# Altium schematic sheet communication.SchDoc
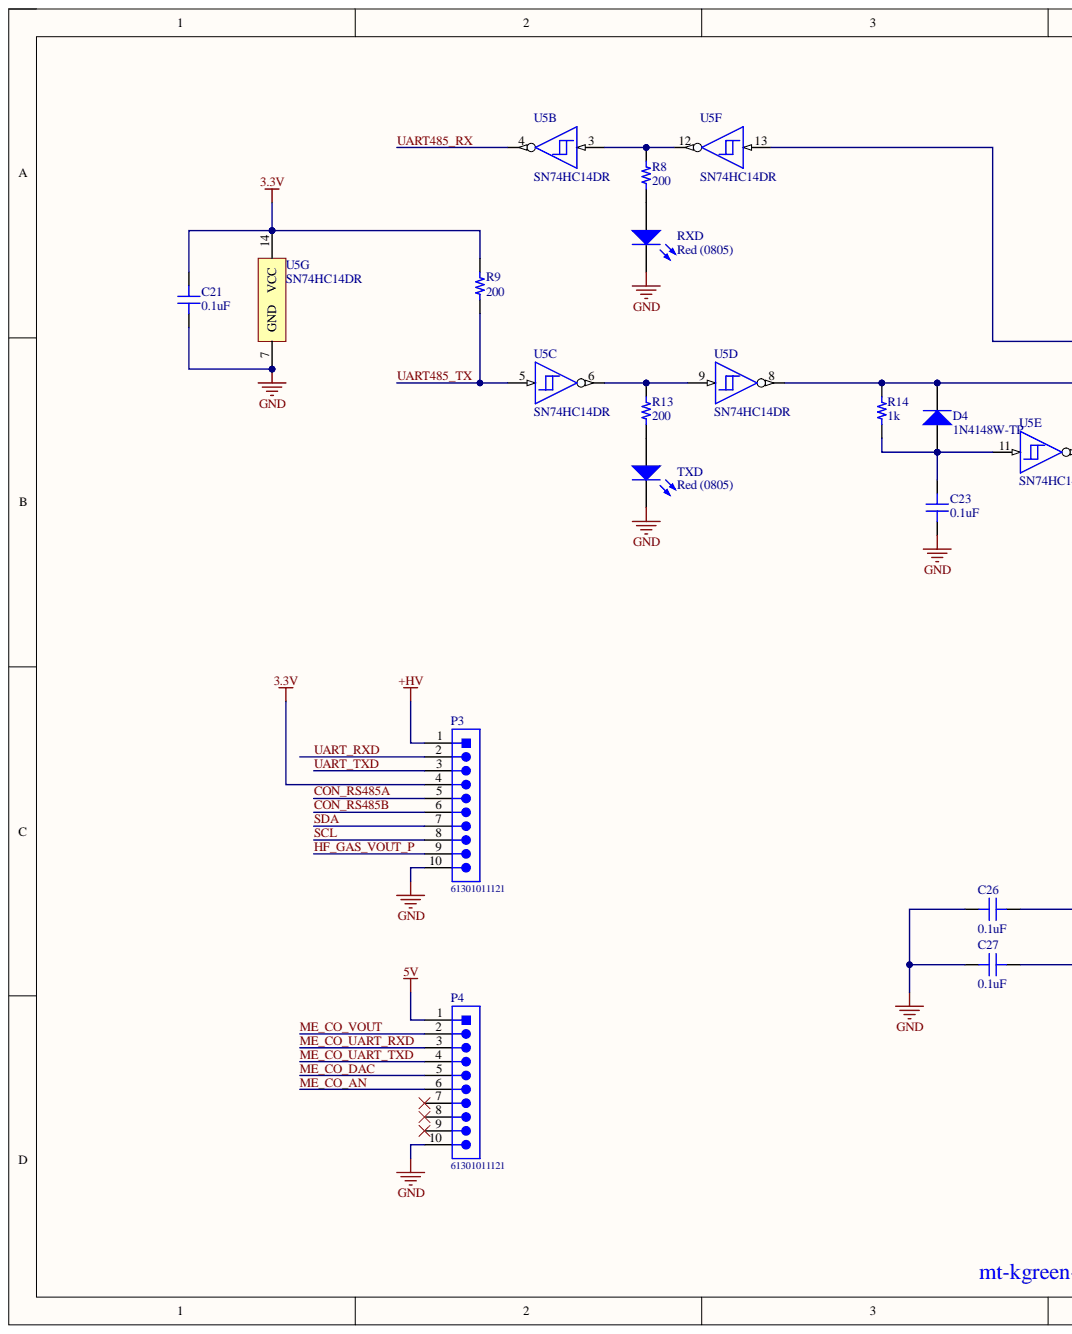
[diagram p0: communication.SchDoc, left]
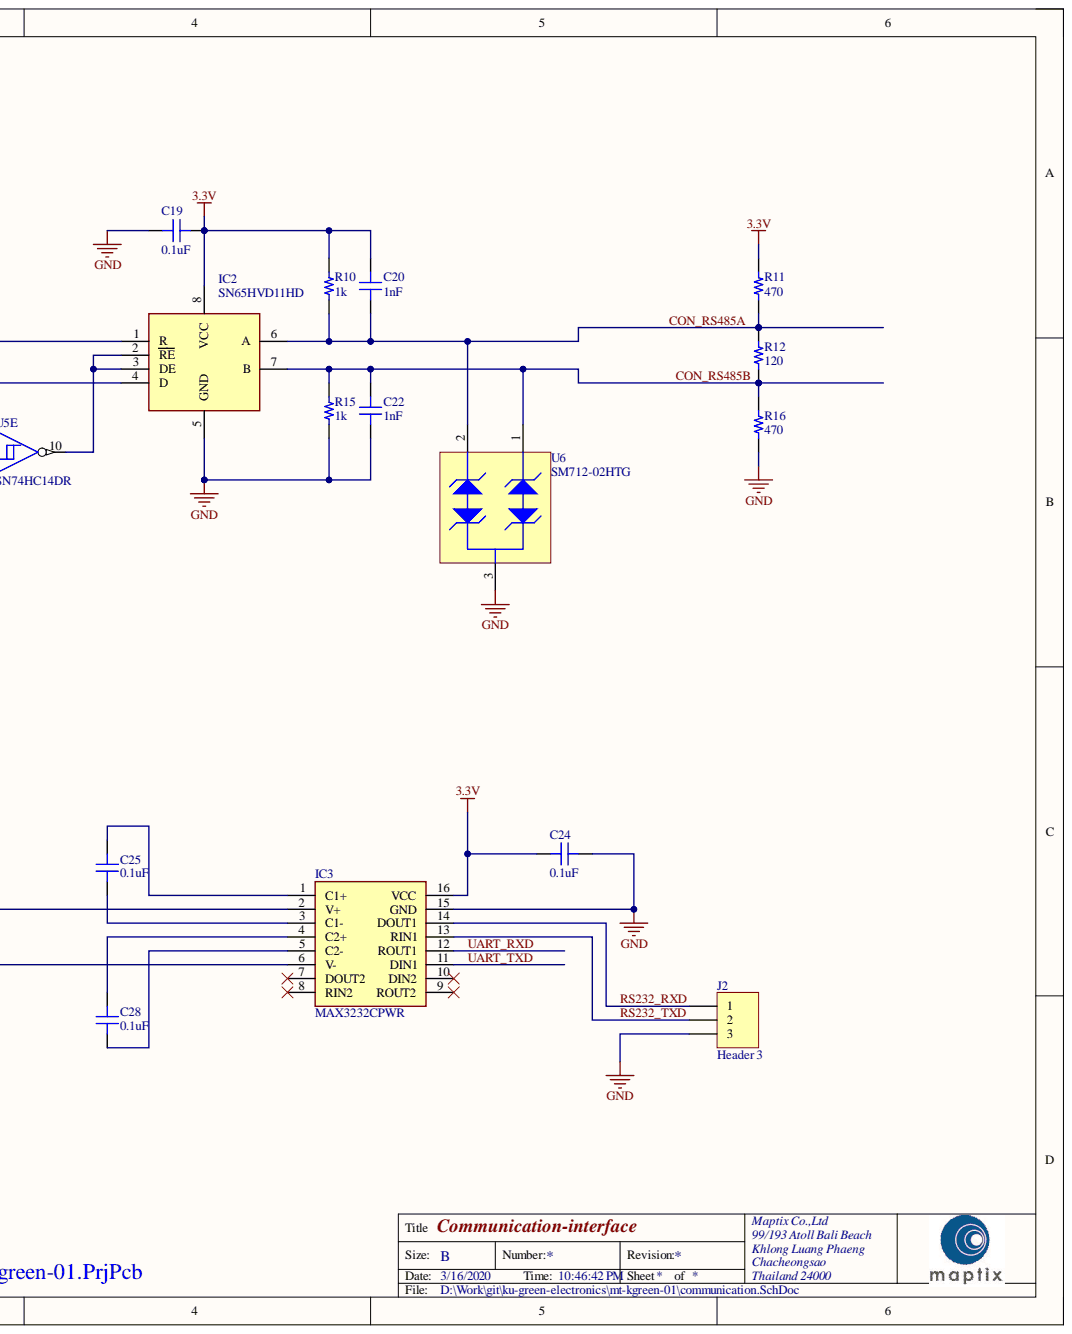
[diagram p1: communication.SchDoc, right]

## Parts
C19  0.1uF  [CAP 0603_1608]
C20  1nF  [CAP 0603_1608]
C21  0.1uF  [CAP 0603_1608]
C22  1nF  [CAP 0603_1608]
C23  0.1uF  [CAP 0603_1608]
C24  0.1uF  [CAP 0603_1608]
C25  0.1uF  [CAP 0603_1608]
C26  0.1uF  [CAP 0603_1608]
C27  0.1uF  [CAP 0603_1608]
C28  0.1uF  [CAP 0603_1608]
D4  1N4148W-TP  [MCC-SOD123_V]
IC2  SN65HVD11HD  [SOIC127P600X175-8N]
IC3  MAX3232CPWR  [SOP65P640X120-16N]
J2  Header 3  [HDR1X3]
P3  61301011121  [61301011121]
P4  61301011121  [61301011121]
R8  200  [RES 0603_1608]
R9  200  [RES 0603_1608]
R10  1k  [RES 0603_1608]
R11  470  [RES 0603_1608]
R12  120  [RES 0603_1608]
R13  200  [RES 0603_1608]
R14  1k  [RES 0603_1608]
R15  1k  [RES 0603_1608]
R16  470  [RES 0603_1608]
RXD  Red (0805)  [0805_A]
TXD  Red (0805)  [0805_A]
U5  SN74HC14DR  [D0014A_N]  (parts B,C,D,E,F,G)
U6  SM712-02HTG  [LFUS-SOT23-3_V]

## Nets
3.3V: C19.2 C20.2 C21.2 C24.1 IC2.8(VCC) IC3.16(VCC) P3.4 R9.2 R10.2 R11.2 U5.14(VCC)
5V: P4.1
+HV: P3.1
GND: C19.1 C21.1 C22.1 C23.1 C24.2 C26.1 C27.1 IC2.5(GND) IC3.15(GND) J2.3 P3.10 P4.10 R15.1 R16.1 RXD.1 TXD.1 U5.7(GND) U6.3
CON_RS485A: C20.1 IC2.6(A) P3.5 R10.1 R11.1 R12.2 U6.2
CON_RS485B: C22.2 IC2.7(B) P3.6 R12.1 R15.2 R16.2 U6.1
HF_GAS_VOUT_P: P3.9
ME_CO_AN: P4.6
ME_CO_DAC: P4.5
ME_CO_UART_RXD: P4.3
ME_CO_UART_TXD: P4.4
ME_CO_VOUT: P4.2
RS232_RXD: IC3.14(DOUT1) J2.1
RS232_TXD: IC3.13(RIN1) J2.2
SCL: P3.8
SDA: P3.7
UART485_RX: U5.4
UART485_TX: R9.1 U5.5
UART_RXD: IC3.12(ROUT1) P3.2
UART_TXD: IC3.11(DIN1) P3.3
NetC23_2: C23.2 D4.1 R14.1 U5.11
NetC25_1: C25.1 IC3.1(C1+)
NetC25_2: C25.2 IC3.3(C1-)
NetC26_2: C26.2 IC3.2(V+)
NetC27_2: C27.2 IC3.6(V-)
NetC28_1: C28.1 IC3.4(C2+)
NetC28_2: C28.2 IC3.5(C2-)
NetD4_2: D4.2 IC2.4(D) R14.2 U5.8
NetIC2_1: IC2.1(R) U5.13
NetIC2_2: IC2.2(RE) IC2.3(DE) U5.10
NetR8_1: R8.1 RXD.2
NetR8_2: R8.2 U5.3 U5.12
NetR13_1: R13.1 TXD.2
NetR13_2: R13.2 U5.6 U5.9

Not connected: IC3 7(DOUT2) 8(RIN2) 9(ROUT2) 10(DIN2); P4 7 8 9

## Library data of the parts
C19-C28 = VJ0603Y332JXACW1BC: CAP CERAMIC 50V X7R 0603; Manufacturer: Vishay Vitramon; Capacitance: 3300pF; Database Table Name: Capacitors - Ceramic - 0603; Tolerance: ±5%; Applications: General Purpose; Component Kind: Standard; ComponentLink1Description: Datasheet; ComponentLink1URL: https://www.vishay.com/docs/28548/vjw1bcbascomseries.pdf; ComponentLink2Description: DigiKey Link; ComponentLink2URL: http://digikey.com/product-detail/en/vishay-vitramon/VJ0603Y332JXACW1BC/720-VJ0603Y332JXACW1BCCT-ND/11198425; Device Package: 0603 (1608 Metric); LastUpdated: 1/2/2020 12:01:35 AM; Library Name: Celestial Altium Library - altium_library.DbLib; Lifecycle Status: Active; Minimum Order: 1; Mounting Type: Surface Mount, MLCC; Operating Temperature: -55°C ~ 125°C; Package / Case: 0603 (1608 Metric); Packaging: Unknown; Part Number: VJ0603Y332JXACW1BC; Part Status: Active; PartId: 26247; Price: 0.00; Series: VJ; Size / Dimension: 0.063" L x 0.031" W (1.60mm x 0.80mm); Supplier 1: DigiKey; Supplier Part Number 1: 720-VJ0603Y332JXACW1BCCT-ND; Temperature Coefficient: X7R; Thickness (Max): 0.034" (0.87mm); Voltage - Rated: 50V
  C19 C21 C23-C28: Value: 0.1uF
  C20 C22: Value: 1nF
D4 = CMP-2000-04993-1: High Speed Switching Diode, 400 mW, 150 mA, -65 to 150 degC, 2-Pin SOD123, RoHS, Tape and Reel; Manufacturer: Micro Commercial Components; Average Forward Current-Max: 0.15A; Peak Current-Max: 2A; Power Dissipation: 400mW; Reverse Recovery Time-Max: 4ns; Reverse Voltage-Max [Vrrm]: 100V; Component Kind: Standard; Datasheet URL: https://datasheet.ciiva.com/16836/1n4148wsod123-16836277.pdf; Datasheet Version: Rev. C, 07/2014; Library Name: Altium Content Vault; Manufacturer URL: http://www.mccsemi.com/; Package Description: 2-Pin Small Outline Diode, Body 2.7 x 1.6 mm, Height 1.35 mm; Package Reference: SOD123; Package URL: http://www.mccsemi.com/up_pdf/1N4448W(SOD-123).pdf
IC2 = SN65HVD11HD: Integrated Circuit; Manufacturer_Name: Texas Instruments; Component Kind: Standard; Datasheet Link: http://www.ti.com/lit/gpn/sn65hvd11-ht; Height: 1.75mm; Library Name: SamacSys.SchLib; Manufacturer_Part_Number: SN65HVD11HD; Mouser Part Number: 595-SN65HVD11HD; Mouser Price/Stock: https://www.mouser.com/Search/Refine.aspx?Keyword=595-SN65HVD11HD
IC3 = MAX3232CPWR: Integrated Circuit; Manufacturer_Name: Texas Instruments; Component Kind: Standard; Datasheet Link: http://www.ti.com/lit/gpn/max3232; Height: 1.2mm; Manufacturer_Part_Number: MAX3232CPWR; Mouser Part Number: 595-MAX3232CPWR; Mouser Price/Stock: https://www.mouser.com/Search/Refine.aspx?Keyword=595-MAX3232CPWR
J2 = Header 3: Header, 3-Pin; Component Kind: Standard; LatestRevisionDate: 17-Jul-2002; LatestRevisionNote: Re-released for DXP Platform.; Library Name: Miscellaneous Connectors.IntLib; Signal Integrity: Connector; Publisher: Altium Limited
P3 P4 = CMP-1502-01082-1: THT Vertical Pin Header WR-PHD, Pitch 2.54 mm, Single Row, 10 pins; Manufacturer: Wurth Elektronik; Contact Resistance: 20mOhm; Gender: Male; Pin Number: 10; Pitch: 2.54mm; Rated Current: 3A; Withstanding Voltage: 500VAC; Working Voltage: 250VAC; Component Kind: Standard; Datasheet URL: https://katalog.we-online.de/em/datasheet/6130xx11121.pdf; Library Name: Altium Content Vault; Manufacturer URL: http://www.we-online.de; Mounting Technology: THT; Operating Temperature: -40to105degC; Orientation: Vertical; Package Reference: 61301011121; Packaging: Bag; Part Number: 61301011121; Row Number: Single; Publisher: WurthElektronik
R8-R16 = CRCW060329K4FKEA: Resistor; Manufacturer: Vishay Dale; Database Table Name: Resistors - Surface Mount - 0603; Power (Watts): 0.1W, 1/10W; Resistance: 29.4 kOhms; Temperature Coefficient: ±100ppm/°C; Tolerance: ±1%; Component Kind: Standard; ComponentLink1Description: Datasheet; ComponentLink1URL: http://www.vishay.com/docs/20035/dcrcwe3.pdf; ComponentLink2Description: DigiKey Link; ComponentLink2URL: http://digikey.com/product-detail/en/vishay-dale/CRCW060329K4FKEA/541-29.4KHCT-ND/1179975; Composition: Thick Film; Device Package: 0603 (1608 Metric); Features: Automotive AEC-Q200; Height - Seated (Max): 0.020" (0.50mm); LastUpdated: 1/2/2020 12:06:58 AM; Library Name: Celestial Altium Library - altium_library.DbLib; Lifecycle Status: Active; Minimum Order: 1; Number of Terminations: 2; Operating Temperature: -55°C ~ 155°C; Package / Case: 0603 (1608 Metric); Packaging: TapeAndReel; Part Number: CRCW060329K4FKEA; Part Status: Active; PartId: 7975; Price: 0.00; Ratings: AEC-Q200; Series: CRCW; Size / Dimension: 0.061" L x 0.033" W (1.55mm x 0.85mm); Supplier 1: DigiKey; Supplier Device Package: 0603; Supplier Part Number 1: 541-29.4KHCT-ND
  R8 R9 R13: Value: 200
  R10 R14 R15: Value: 1k
  R11 R16: Value: 470
  R12: Value: 120
RXD TXD = CMP-1426-00007-1: SMD mono-color Chip LED, WL-SMCW, Green; Manufacturer: Wuerth Elektronik; PartNumber: 150080GS75000; Color: Green; Component Kind: Standard; ComponentLink1Description: Manufacturer URL; ComponentLink1URL: http://www.we-online.com; ComponentLink2Description: Datasheet; ComponentLink2URL: http://katalog.we-online.com/led/datasheet/150080GS75000.pdf; Library Name: Altium Content Vault; PackageReference: 0805_A
U5 = CMP-1615-00273-3: Hex Schmitt-Trigger Inverters, D0014A, LARGE T&R; Manufacturer: Texas Instruments; Bits(#): 6; F @ Nom Voltage(Max)(Mhz): 20; ICC @ Nom Voltage(Max)(mA): 0.02; Input Type: CMOS; Output Drive (IOL/IOH)(Max)(mA): -4/4; Rating: Catalog; Schmitt Trigger: Yes; Technology Family: HC; tpd @ Nom Voltage(Max)(ns): 31; VCC(Min)(V): 2; Voltage(Nom)(V): 5; Code_JEDEC: MS-012; Component Kind: Standard; DatasheetVersion: SCLS085G; Generic Part Number: SN74HC14; Library Name: Altium Content Vault; Operating Temperature Range(C): -40 to 85; Output Type: CMOS; PackageReference: D0014A; VCC(Max)(V): 6
U6 = CMP-2000-07561-1: TVS Diode Array, 600 W, 12 V, -40 to 125 degC, 3-Pin SOT23, RoHS, Tape and Reel; Manufacturer: Littelfuse; Breakdown Voltage: 13.3V; Capacitance: 75pF; Case\/Package: TO-236-3; Clamping Voltage: 31V; Direction: Bidirectional; Element Configuration: Dual; Leakage Current: 20uA; Max Power Dissipation: 600W; Max Surge Current: 19A; Number of Bidirectional Channels: 2; Power Line Protection: No; Reverse Standoff Voltage: 12V; Component Kind: Standard; Datasheet URL: http://www.littelfuse.com/~/media/electronics/datasheets/tvs_diode_arrays/littelfuse_tvs_diode_array_sm712_datasheet.pdf.pdf; Datasheet Version: 12/2016; Depth: 1.4mm; Lead Free: LeadFree; Length: 3.04mm; Library Name: Altium Content Vault; Manufacturer URL: http://www.littelfuse.com/; Max Operating Temperature: 125degC; Min Breakdown Voltage: 13.3V; Min Operating Temperature: -40degC; Mount: SurfaceMount; Mounting Technology: SurfaceMount; Number of Channels: 2; Number of Pins: 3; Package Description: 3-Pin Surface Mount Device, Body 2.92 x 1.3 mm, Pitch 0.95 mm; Package Quantity: 3000; Package Reference: SOT23-3; Packaging: TapeandReel; Peak Pulse Current: 19A; Peak Pulse Power: 600W; Polarity: Bidirectional; Radiation Hardening: No; RoHSCompliant: True; Termination: SMD/SMT; Working Voltage: 12V
